# Altium schematic sheet Sheet2.SchDoc(Sheet2)
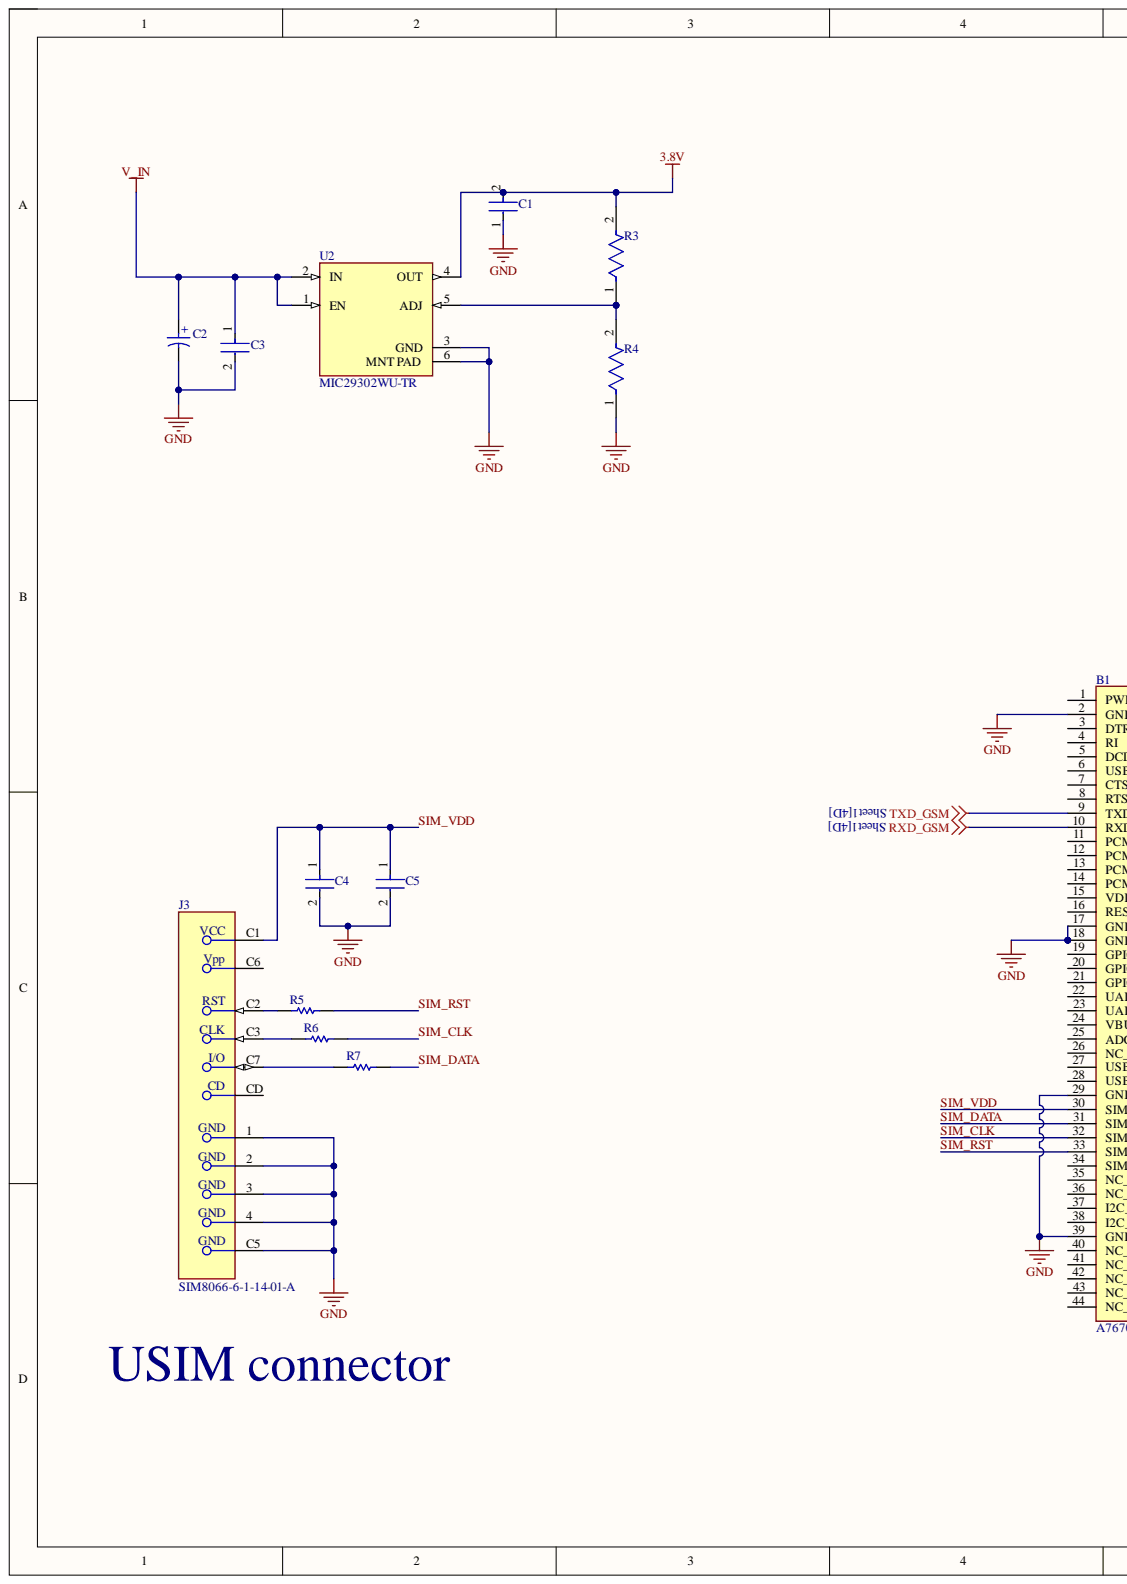
[diagram p0: Sheet2.SchDoc, left]
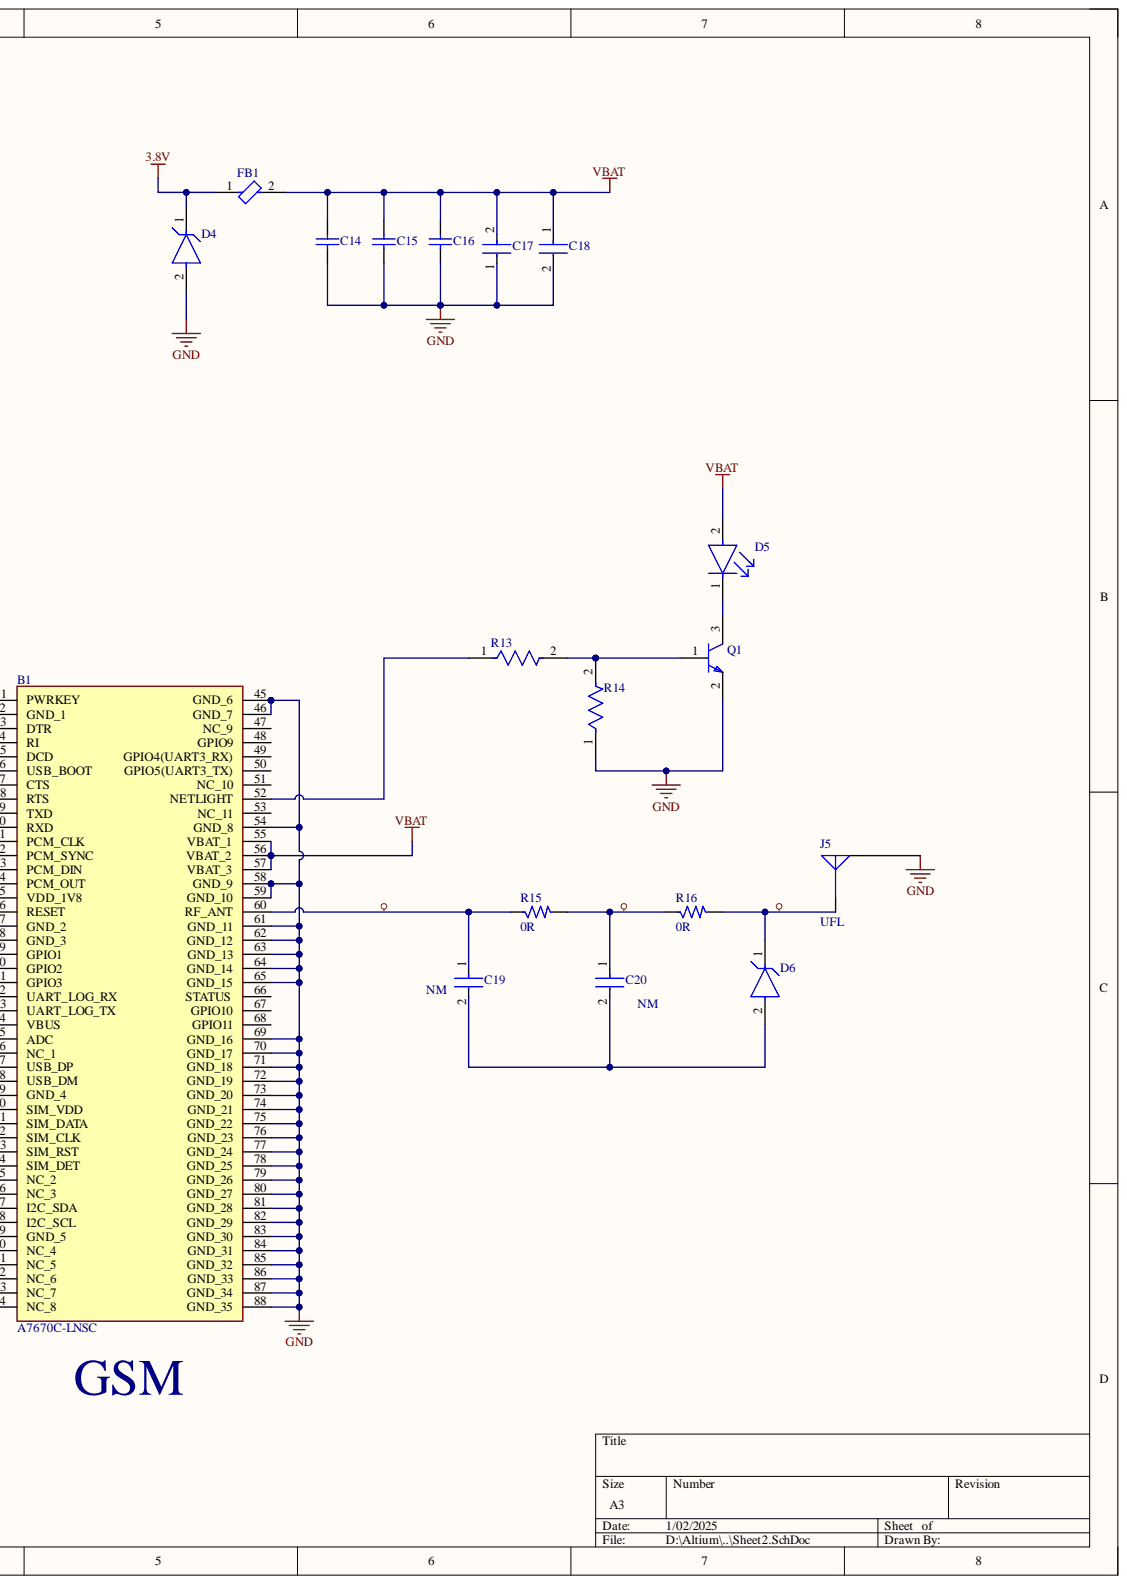
[diagram p1: Sheet2.SchDoc, right]

## Parts
B1  A7670C-LNSC  [A7670CLNSC]
C1  GRM155R71C104KA88D  [FP-GRM15-MFG]  (value not drawn)
C2  865060653010  [WCAP-ASLL_D8H10.5]  (value not drawn)
C3  C0402C105K8PACTU  [FP-C0402C105K8PACTU-MFG]  (value not drawn)
C4  C0402C104K4RACTU  [FP-C0402-BB-MFG]  (value not drawn)
C5  04025A330JAT2A  [FP-0402-L_1_0_1-W_0_5_0_1-IPC_A]  (value not drawn)
C14  GRM31CR60J107ME39L  [CAPC3216X190X55ML30T25]  (value not drawn)
C15  GRM31CR60J107ME39L  [CAPC3216X190X55ML30T25]  (value not drawn)
C16  GRM21BR71H105KA12L  [CAPC2013X135X45LL10T25]  (value not drawn)
C17  GRM155R71C104KA88D  [FP-GRM15-MFG]  (value not drawn)
C18  CC0603JRNPO9BN330  [FP-CC0603-0_9-IPC_C]  (value not drawn)
C19  04025C222KAT2A  [FP-0402-L_1_0_1-W_0_5_0_1-MFG]  (value not drawn)
C20  C0402C104K4RACTU  [FP-C0402-BB-MFG]  (value not drawn)
D4  SMAJ6.0A  [FP-SMAJ-MFG]  (value not drawn)
D5  LTST-C190GKT  [FP-LTST-C190GKT-MFG]  (value not drawn)
D6  SMAJ6.0A  [FP-SMAJ-MFG]  (value not drawn)
FB1  BLM21PG300SN1D  [FP-BLM21-0_2-t0_85-e0_5-d-MFG]  (value not drawn)
J3  SIM8066-6-1-14-01-A  [FP-SIM8066-6-1-14-01-A-MFG]
J5  UFL  [MOLEX SD-734120110]
Q1  BC846B,215  [FP-SOT23-IPC_B]  (value not drawn)
R3  CRCW0402100KFKED  [FP-CRCW0402-e3-IPC_C]  (value not drawn)
R4  CRCW060347K0FKEA  [FP-CRCW0603-e3-IPC_B]  (value not drawn)
R5  CRCW040222R0FKED  [RESC1005X40X25LL05T05]  (value not drawn)
R6  CRCW040222R0FKED  [RESC1005X40X25LL05T05]  (value not drawn)
R7  CRCW040222R0FKED  [RESC1005X40X25LL05T05]  (value not drawn)
R13  CRCW06034K70FKEA  [FP-CRCW0603-e3-IPC_A]  (value not drawn)
R14  CRCW060347K0FKEA  [FP-CRCW0603-e3-IPC_B]  (value not drawn)
R15  0R  [RES_0805]
R16  0R  [RES_0805]
U2  MIC29302WU-TR  [FP-C04-012-MFG]

## Nets
3.8V: C1.2 D4.1 FB1.1 R3.2 U2.4(OUT)
GND: B1.2(GND_1) B1.17(GND_2) B1.18(GND_3) B1.29(GND_4) B1.39(GND_5) B1.45(GND_6) B1.46(GND_7) B1.54(GND_8) B1.58(GND_9) B1.59(GND_10) B1.61(GND_11) B1.62(GND_12) B1.63(GND_13) B1.64(GND_14) B1.65(GND_15) B1.69(GND_16) B1.70(GND_17) B1.71(GND_18) B1.72(GND_19) B1.73(GND_20) B1.74(GND_21) B1.75(GND_22) B1.76(GND_23) B1.77(GND_24) B1.78(GND_25) B1.79(GND_26) B1.80(GND_27) B1.81(GND_28) B1.82(GND_29) B1.83(GND_30) B1.84(GND_31) B1.85(GND_32) B1.86(GND_33) B1.87(GND_34) B1.88(GND_35) C1.1 C2.2 C3.2 C4.2 C5.2 C14.1 C15.1 C16.1 C17.1 C18.2 D4.2 J3.1 J3.2 J3.3 J3.4 J3.C5 J5.G Q1.2 R4.1 R14.1 U2.3(GND) U2.6(MNT_PAD)
RXD_GSM: B1.10(RXD)
TXD_GSM: B1.9(TXD)
V_IN: C2.1 C3.1 U2.1(EN) U2.2(IN)
VBAT: B1.55(VBAT_1) B1.56(VBAT_2) B1.57(VBAT_3) C14.2 C15.2 C16.2 C17.2 C18.1 D5.2 FB1.2
SIM_CLK: B1.32(SIM_CLK) R6.2
SIM_DATA: B1.31(SIM_DATA) R7.2
SIM_RST: B1.33(SIM_RST) R5.2
SIM_VDD: B1.30(SIM_VDD) C4.1 C5.1 J3.C1
NetB1_52: B1.52(NETLIGHT) R13.1
NetB1_60: B1.60(RF_ANT) C19.1 R15.1
NetC19_2: C19.2 C20.2 D6.2
NetC20_1: C20.1 R15.2 R16.1
NetD5_1: D5.1 Q1.3
NetD6_1: D6.1 J5.S R16.2
NetJ3_C2: J3.C2 R5.1
NetJ3_C3: J3.C3 R6.1
NetJ3_C7: J3.C7 R7.1
NetQ1_1: Q1.1 R13.2 R14.2
NetR3_1: R3.1 R4.2 U2.5(ADJ)

Not connected: B1 1(PWRKEY) 3(DTR) 4(RI) 5(DCD) 6(USB_BOOT) 7(CTS) 8(RTS) 11(PCM_CLK) 12(PCM_SYNC) 13(PCM_DIN) 14(PCM_OUT) 15(VDD_1V8) 16(RESET) 19(GPIO1) 20(GPIO2) 21(GPIO3) 22(UART_LOG_RX) 23(UART_LOG_TX) 24(VBUS) 25(ADC) 26(NC_1) 27(USB_DP) 28(USB_DM) 34(SIM_DET) 35(NC_2) 36(NC_3) 37(I2C_SDA) 38(I2C_SCL) 40(NC_4) 41(NC_5) 42(NC_6) 43(NC_7) 44(NC_8) 47(NC_9) 48(GPIO9) 49(GPIO4(UART3_RX)) 50(GPIO5(UART3_TX)) 51(NC_10) 53(NC_11) 66(STATUS) 67(GPIO10) 68(GPIO11); J3 C6 CD

## Library data of the parts
B1 = A7670C-LNSC: MODULE LTE CAT1; Manufacturer_Name: SIMCOM; Geometry.Height: 2.77mm; Component Kind: Standard; Datasheet Link: https://nostris.ee/pdf/A7670%20Series%20Hardware%20Design_V1.00.pdf; Library Name: A7670C-LNSC.SchLib; Manufacturer_Part_Number: A7670C-LNSC
C1 C17 = CMP-2100-03641-2: CAP CER 0.1UF 16V X7R 0402; Manufacturer: Murata; Capacitance: 0.10μF; Material: Ceramic; Standoff Height: 0mm; Tolerance: 10%; Value: 0.10μF; Voltage Rating: 16Vdc; Category: Cap; Component Kind: Standard; ComponentLink2Description: Datasheet; ComponentLink2URL: https://search.murata.co.jp/Ceramy/image/img/A01X/G101/ENG/GRM155R71H471KA01-01A.pdf; Device Class L1: Passive Components; Device Class L2: Capacitors; Device Class L3: Ceramic Capacitors; Digikey Description: CAP CER 0.1UF 16V X7R 0402; Height: 0.55mm; Ipc Land Pattern Name: CAPC10050X219; Lead Free: yes; Library Name: Altium Content Vault; Manufacturer Part Number: GRM155R71C104KA88D; Mouser Description: 0402 0.1 uF 16V ±10 % Tolerance X7R SMT Multilayer Ceramic Capacitor; Octopart: https://octopart.com/grm155r71c104ka88d-murata-196880; Package: GRM15; RoHS: TRUE; Temperature: -55°C to +125°C; Temperature Characteristic: X7R; Temperature Range High: +125°C; Temperature Range Low: -55°C
C2 = CMP-03913-54202488-2: 865060653010 Aluminum Electrolytic Capacitor, Polarized, Aluminum (wet), 50V, 20% +Tol, 20% -Tol, 100uF, Surface Mount, 3333; Capacitance: 100µF; ESR (Equivalent Series Resistance): 227.529mΩ; Impedance: 340mΩ; Insulation Resistance: 1MΩ; Leakage Current: 50µA; Life (Hours): 5000hours; Polarity: Polar; Ripple Current: 350mA; Case/Package: Radial; Component Kind: Standard; Diameter: 8mm; Height: 10.5mm; Height - Seated (Max): 10.4902mm; Length: 10.5mm; Library Name: Altium Content Vault; Max Operating Temperature: 105°C; Min Operating Temperature: -55°C; Mount: Surface Mount; NexarPartId: 54202488; REACH SVHC: Unknown; Ripple Current (AC): 350mA; RoHS: Compliant; Tolerance: 20%; Voltage Rating: 50V; Voltage Rating (DC): 50V
C3 = CMP-2008-02081-2: CAP CER 1UF 10V X5R 0402; Dielectric Material: Ceramic; Dissipation Factor: 5%; Tolerance: 10%; Capacitance: 1uF; Case Code (Imperial): 0402; Case Code (Metric): 1005; Case/Package: 0402; Component Kind: Standard; Construction: Flat; Contact Plating: Tin; Depth: 0.5mm; Dielectric: X5R; Height: 0.5mm; Lead Free: Lead Free; Length: 1mm; Library Name: Altium Content Vault; Max Operating Temperature: 85°C; Min Operating Temperature: -55°C; Mount: Surface Mount; Number of Pins: 2; Package Quantity: 1; Packaging: Tape and Reel; Radiation Hardening: No; REACH SVHC: No SVHC; RoHS Compliant: Yes; Series: C; Termination: SMD/SMT; Thickness: 0.022inch; Voltage: 10V; Voltage Rating: 10V; Voltage Rating (DC): 10V; Width: 0.5mm
C4 C20 = CMP-2008-02108-2: CAP CER 0.1UF 16V X7R 0402; Manufacturer: Kemet; Capacitance: 0.10 μF; Material: Ceramic; Temperature Coefficient: 15%; Tolerance: 0.1; Value: 0.10 μF; Automotive: No; Category: Cap; Component Kind: Standard; ComponentLink2Description: Datasheet; ComponentLink2URL: https://content.kemet.com/datasheets/KEM_C1002_X7R_SMD.pdf; Device Class L1: Passive Components; Device Class L2: Capacitors; Device Class L3: Ceramic Capacitors; Digikey Description: CAP CER 0.1UF 16V X7R 0402; Height: 0.55mm; Ipc Land Pattern Name: CAPC10050X50; Lead Free: Yes; Library Name: Altium Content Vault; Manufacturer Part Number: C0402C104K4RACTU; Mouser Description: Cap Ceramic 0.1uF 16V X7R 10% SMD 0402 125?C Paper T/R - Tape and Reel (Alt: C0402C104K4RAC TU); Octopart: https://octopart.com/c0402c104k4ractu-kemet-94158; Package: C0402-BB; RoHS: TRUE; Temperature: -55°C to +125°C; Temperature Characteristic: X7R; Temperature Range High: +125°C; Temperature Range Low: -55°C; Voltage Rating: 16 V
C5 = CMP-2008-02637-2: General Purpose Ceramic Capacitor, 0402, 33pF, 5%, C0G, 30ppm/°C, 50V; Insulation Resistance: 100GR; Capacitance: 33pF; Case Code (Imperial): 0402; Case Code (Metric): 1005; Case/Package: 0402; Component Kind: Standard; Construction: Flat; Contact Plating: Tin; Depth: 0.5mm; Dielectric: C0G; Height: 0.5mm; Lead Free: Lead Free; Length: 1mm; Library Name: Altium Content Vault; Max Operating Temperature: 125°C; Min Operating Temperature: -55°C; Mount: Surface Mount; Number of Pins: 2; Package Quantity: 1; Packaging: Tape and Reel; Radiation Hardening: No; REACH SVHC: No SVHC; RoHS Compliant: Yes; Series: -; Temperature Coefficient: 30ppm/°C; Termination: SMD/SMT; Thickness: 0.022inch; Tolerance: 5%; Voltage: 50V; Voltage Rating: 50V; Voltage Rating (DC): 50V; Width: 0.5mm
C14 C15 = CMP-1662-00011-2: Chip Capacitor, 100 uF, +/- 20%, 6.3 V, -55 to 85 degC, 1206 (3216 Metric), RoHS, Tape and Reel; Capacitance: 100 uF; Case\/Package: 1206; Type (Capacitors): X5R; Voltage Rating: 6.3 V; Case Code (Imperial): 1206; Case Code (Metric): 3216; Component Kind: Standard; ComponentLink1Description: Manufacturer URL; ComponentLink1URL: http://www.murata.com/; ComponentLink2Description: Datasheet; ComponentLink2URL: http://psearch.en.murata.com/capacitor/product/GRM31CR60J107ME39%23.pdf; DatasheetVersion: 09/2016; Height: 1.6 mm; Length: 3.2 mm; Library Name: Altium Content Vault; Max Operating Temperature: 85 degC; Min Operating Temperature: -55 degC; Mount: Surface Mount; Mounting Technology: Surface Mount; Package Quantity: 2000; PackageDescription: 2-Pin Surface Mount Device, Body 3.2 x 1.6 mm; PackageReference: 1206; Packaging: Tape and Reel; PartNumber: GRM31CR60J107ME39L; Pins: 2; Radiation Hardening: No; REACH SVHC: No SVHC; RoHSCompliant: true; Tolerance: 20%; Voltage Rating (DC): 6.3 V; Width: 1.6 mm
C16 = CMP-1036-04761-2: Manufacturer: Murata; Component Kind: Standard; Library Name: Altium Content Vault; Part Number: GRM21BR71H105KA12L
C18 = CMP-2000-06072-2: CAP CER 33PF 50V C0G/NPO 0603; Dielectric: C0G; Dielectric Material: Ceramic; Insulation Resistance: 100GR; Temperature Coefficient: 30ppm/°C; Tolerance: 5%; Capacitance: 33pF; Case Code (Imperial): 0603; Case Code (Metric): 1608; Case/Package: 0603; Component Kind: Standard; Depth: 0.8mm; Height: 0.8mm; Lead Free: Lead Free; Length: 1.6mm; Library Name: Altium Content Vault; Max Operating Temperature: 125°C; Min Operating Temperature: -55°C; Mount: Surface Mount; Number of Pins: 2; Package Quantity: 1; Packaging: Tape and Reel; Radiation Hardening: No; REACH SVHC: No SVHC; Resistor Type: Ceramic; RoHS Compliant: Yes; Series: CC; Termination: SMD/SMT; Thickness: 0.035inch; Voltage: 50V; Voltage Rating: 50V; Voltage Rating (DC): 50V; Width: 0.031inch
C19 = CMP-2008-02711-2: General Purpose Ceramic Capacitor, 0402, 2.2nF, 10%, X7R, 15%, 50V; Capacitance: 2.2nF; Case Code (Imperial): 0402; Case Code (Metric): 1005; Case/Package: 0402; Component Kind: Standard; Construction: Flat; Contact Plating: Tin; Depth: 0.5mm; Dielectric: X7R; Dielectric Material: Ceramic; Height: 0.56mm; Lead Free: Lead Free; Length: 1mm; Library Name: Altium Content Vault; Max Operating Temperature: 125°C; Min Operating Temperature: -55°C; Mount: Surface Mount; Number of Pins: 2; Package Quantity: 1; Packaging: Tape and Reel; Radiation Hardening: No; REACH SVHC: No SVHC; RoHS Compliant: Yes; Series: -; Termination: SMD/SMT; Thickness: 0.022inch; Tolerance: 10%; Voltage: 50V; Voltage Rating: 50V; Voltage Rating (DC): 50V; Width: 0.02inch
D4 D6 = CMP-08607-000083-1: TVS DIODE 6V 10.3V DO214AC; Manufacturer: Littelfuse; Breakdown Voltage: 6.67V; Direction: Unidirectional; Max Junction Temp: +150°C; Number Of Channels: 1; Peak Pulse Current: 38.8A; Peak Pulse Power: 400W; Automotive: No; Category: Diode; Clamping Voltage: 10.3V; Component Kind: Standard; ComponentLink2Description: Datasheet; ComponentLink2URL: https://www.littelfuse.com/~/media/electronics/datasheets/tvs_diodes/littelfuse_tvs_diode_smaj_datasheet.pdf.pdf; Device Class L1: Circuit Protection; Device Class L2: TVS Diodes; Device Class L3: unset; Digikey Description: TVS DIODE 6V 10.3V DO214AC; Height: 2.29mm; Lead Free: Yes; Library Name: Altium Content Vault; Manufacturer Part Number: SMAJ6.0A; Mouser Description: TVS Diodes - Transient Voltage Suppressors 60Vr 400W 4.1A 5% UniDirectional; Octopart: https://octopart.com/smaj6.0a-littelfuse-350212; Package: SMAJ; Reverse Standoff Voltage: 6V; RoHS: TRUE; Temperature: -65°C to +150°C; Temperature Range High: +150°C; Temperature Range Low: -65°C
D5 = CMP-22017-000005-2: LED GREEN CLEAR CHIP SMD; Dominant Wavelength: 569nm; Forward Current: 30mA; Forward Voltage: 2.1V; Lens Color: Colorless; Luminous Intensity: 6mcd; Number of Elements: 1; Power Dissipation: 100mW; Reverse Voltage: 5V; Test Current: 10mA; Viewing Angle: 130°; Voltage: 2V; Wavelength: 565nm; Case Code (Imperial): 0603; Case Code (Metric): 1608; Case/Package: 0603; Color: Green; Component Kind: Standard; Depth: 0.8mm; Height: 0.8mm; Illumination Color: Green; Lead Free: Lead Free; Length: 1.6mm; Lens Style: Clear; Lens Transparency: Clear; Library Name: Altium Content Vault; Max Operating Temperature: 85°C; Min Operating Temperature: -55°C; Mount: Surface Mount; Number of LEDs: 1; Number of Pins: 2; Package Quantity: 1; Packaging: Tape and Reel; Power Rating: 100mW; Radiation Hardening: No; Width: 0.8mm
FB1 = CMP-0686-00620-3: Chip Ferrite Bead, 0805, 30Ω @ 100MHz, 0.014Ω, , 4A; DC Current: 3A; DC Resistance (DCR): 14mR; Impedance: 30R; Material: Steel; Number of Circuits: 1; Resistance: 15mR; Shielding: Unshielded; Tolerance: 25%; Case Code (Imperial): 0805; Case Code (Metric): 2012; Case/Package: 0805; Component Kind: Standard; Core Material: Ferrite; Current Rating: 4A; DC Resistance-Max: 14mR; Depth: 1.25mm; Frequency: 100MHz; Height: 0.85mm; Lead Free: Lead Free; Length: 2mm; Library Name: Altium Content Vault; Max Current Rating: 4A; Max DC Current: 3A; Max Operating Temperature: 125°C; Military Standard: Not; Min Operating Temperature: -55°C; Number of Lines: 1; Number of Pins: 2; Package Quantity: 1; Packaging: Tape and Reel; Radiation Hardening: No; REACH SVHC: No SVHC; RoHS Compliant: Yes; Series: BLM21P; Termination: SMD/SMT; Test Frequency: 100MHz; Width: 1.25mm
J3 = CMP-03329-117635865-1: SIM8066-6-1-14-01-A Memory Card Connectors 1.44mm Nano SIM Conn w/Peg and Switch; Current Rating: 1A; Number of Contacts: 6; Voltage Rating: 30V; Component Kind: Standard; Contact Material: Gold, Nickel; Library Name: Altium Content Vault; Max Operating Temperature: 85°C; Min Operating Temperature: -40°C; NexarPartId: 117635865; Number of Pins: 6
J5 = UFL MOLEX SD-734120110: Component Kind: Standard; Library Name: Conn RF.IntLib; PartNumber: MOLEX SD-734120110
Q1 = CMP-14406-000027-1: TRANS NPN 65V 0.1A SOT23; Component Kind: Standard; Library Name: Altium Content Vault; RoHS Compliant: Yes
R3 = CMP-2002-08123-3: RES Thick Film, 100kΩ, 1%, 0.063W, 100ppm/°C, 0402; Max Power Dissipation: 63mW; Resistance: 100kR; Voltage Rating: 50V; Case Code (Imperial): 0402; Case Code (Metric): 1005; Case/Package: 0402; Component Kind: Standard; Composition: Thick Film; Contact Plating: Tin; Depth: 0.5mm; Features: Automotive AEC-Q200; Height: 0.35mm; Lead Free: Lead Free; Length: 1mm; Library Name: Altium Content Vault; Max Operating Temperature: 155°C; Military Standard: Not; Min Operating Temperature: -55°C; Mount: Surface Mount; Number of Pins: 2; Number of Terminations: 2; Package Quantity: 10000; Packaging: Tape and Reel; Power Rating: 63mW; Radiation Hardening: No; Temperature Coefficient: 100ppm/°C; Termination: SMD/SMT; Tolerance: 1%; Voltage Rating (DC): 50V; Weight: 2.3E-05oz; Width: 0.02inch
R4 R14 = CMP-2000-03379-3: RES SMD 47K OHM 1% 1/10W 0603; Max Power Dissipation: 100mW; Resistance: 47kR; Temperature Coefficient: 100ppm/°C; Voltage Rating: 75V; Case Code (Imperial): 0603; Case Code (Metric): 1608; Case/Package: 0603; Component Kind: Standard; Construction: Rectangular; Contact Plating: Tin; Depth: 0.85mm; Features: Automotive AEC-Q200; Height: 0.45mm; Lead Free: Lead Free; Length: 1.55mm; Library Name: Altium Content Vault; Max Operating Temperature: 155°C; Military Standard: Not; Min Operating Temperature: -55°C; Mount: Surface Mount; Number of Terminations: 2; Package Quantity: 5000; Packaging: Tape and Reel; Power Rating: 100mW; Radiation Hardening: No; Resistance Tolerance: 1%; Resistor Type: Thick Film; RoHS Compliant: Yes; Series: CRCW; Size Code: 0603; Technology: THICK FILM; Termination: SMD/SMT; Tolerance: 1%; Voltage Rating (DC): 75V; Weight: 7.1E-05oz; Width: 0.85mm
R5-R7 = CMP-2002-08382-1: Manufacturer: Vishay Dale; Component Kind: Standard; Library Name: Altium Content Vault; Part Number: CRCW040222R0FKED
R13 = CMP-1666-00019-3: RES Thick Film, 4.7kΩ, 1%, 0.1W, 100ppm/°C, 0603; Power Rating: 100mW; Resistance: 4.7kR; Voltage Rating: 75V; Case Code (Imperial): 0603; Case Code (Metric): 1608; Case/Package: 0603; Component Kind: Standard; Composition: Thick Film; Contact Plating: Tin; Depth: 0.85mm; Height: 0.45mm; Lead Free: Lead Free; Length: 1.55mm; Library Name: Altium Content Vault; Max Operating Temperature: 155°C; Military Standard: Not; Min Operating Temperature: -55°C; Mount: Surface Mount; Package Quantity: 5000; Packaging: Tape and Reel; Temperature Coefficient: 100ppm/°C; Termination: SMD/SMT; Tolerance: 1%; Voltage Rating (DC): 75V; Weight: 7.1E-05oz; Width: 0.85mm
R15 R16 = RES_0R-0.125W-1%-0805: 0 Ohms Jumper 0.125W, 1/8W Chip Resistor 0805 (2012 Metric) Automotive AEC-Q200 Thick Film; Alternate Part Number-1: WR08X000PTL; Manufacturer: Walsin; Case/Package: 0805; Component Kind: Standard; Library Name: ESTEEMPCBLIB.IntLib; Manufacturer Part Number: WR08X000PTL; Supplier Part Number-1: WR08X000PTL; Supplier-1: SIRICOM
U2 = CMP-06324-000007-1: IC REG LINEAR POS ADJ 3A TO263-5; Manufacturer: Microchip; Accuracy Percentage: 1%; Dropout Voltage: 370mV; Max Junction Temp: +125°C; Max Supply Voltage: 26V; Min Supply Voltage: 2.25V; Nominal Supply Current: 10-37mA; Number Of Outputs: 1; Output Type: AdjustableProgrammable; Output Voltage: 3.3-12V; Standoff Height: 0mm; Automotive: No; Category: IC; Component Kind: Standard; ComponentLink2Description: Datasheet; ComponentLink2URL: http://ww1.microchip.com/downloads/en/DeviceDoc/MIC2915x-30x-50x-75x-High-Current-Low-Dropout-Regulators-DS20005685B.pdf; Device Class L1: Integrated Circuits (ICs); Device Class L2: Power Management ICs; Device Class L3: Voltage Regulators - Linear; Digikey Description: IC REG LINEAR POS ADJ 3A TO263-5; Height: 0.2inch; Lead Free: Yes; Library Name: Altium Content Vault; Manufacturer Part Number: MIC29302WU-TR; Mouser Description: LDO Voltage Regulators; Octopart: https://octopart.com/mic29302wu-tr-microchip-66801318; Output Current: 3A; Package: C04-012; RoHS: TRUE; Temperature: -40°C to +125°C; Temperature Range High: +125°C; Temperature Range Low: -40°C
